# Altium schematic sheet FPSModule.SchDoc(FPSModule)
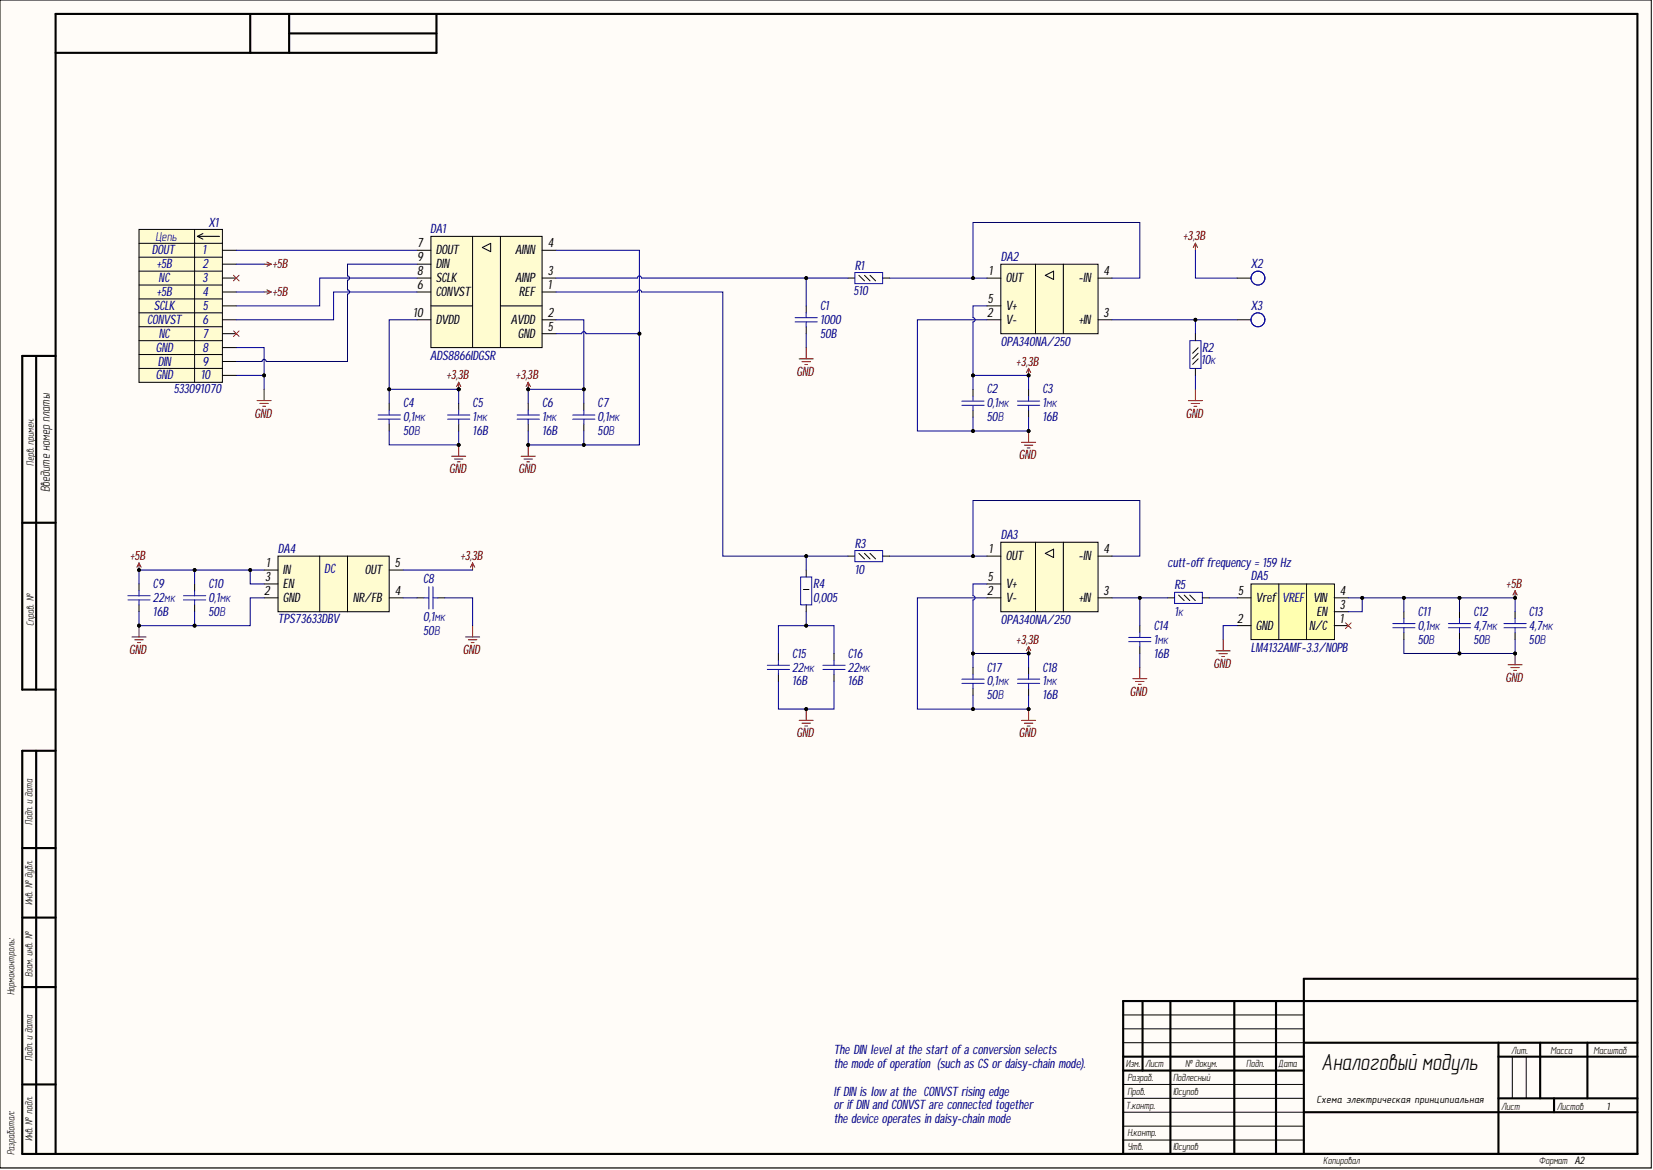
[diagram p0: FPSModule.SchDoc]

## Parts
C1  1000  Voltage: 50B  [C0402]
C2  0,1мк  Voltage: 50В  [C0402]
C3  1мк  Voltage: 16B  [C0603]
C4  0,1мк  Voltage: 50В  [C0402]
C5  1мк  Voltage: 16B  [C0603]
C6  1мк  Voltage: 16B  [C0603]
C7  0,1мк  Voltage: 50В  [C0402]
C8  0,1мк  Voltage: 50В  [C0402]
C9  22мк  Voltage: 16B  [C1210]
C10  0,1мк  Voltage: 50В  [C0402]
C11  0,1мк  Voltage: 50В  [C0402]
C12  4,7мк  Voltage: 50В  [C0805]
C13  4,7мк  Voltage: 50В  [C0805]
C14  1мк  Voltage: 16B  [C0603]
C15  22мк  Voltage: 16B  [C1210]
C16  22мк  Voltage: 16B  [C1210]
C17  0,1мк  Voltage: 50В  [C0402]
C18  1мк  Voltage: 16B  [C0603]
DA1  ADS8866  [MSOP10]
DA2  OPA340  Part Number: OPA340NA/250  [SOT23-5]
DA3  OPA340  Part Number: OPA340NA/250  [SOT23-5]
DA4  Part Number: TPS73633DBV  [SOT23-5]  (hidden comment: TPS736DBV)
DA5  LM4132  [SOT23-5]
R1  value 510  [R0402]  (hidden comment: Resistor_0,062Вт)
R2  value 10к  [R0402]  (hidden comment: Resistor_0,062Вт)
R3  value 10  [R0402]  (hidden comment: Resistor_0,062Вт)
R4  value 0,005  [R1206]  (hidden comment: Resistor_1Вт)
R5  value 1к  [R0402]  (hidden comment: Resistor_0,062Вт)
X1  Contact_1: DOUT  Contact_2: +5B  Contact_3: NC  Contact_5: SCLK  Contact_6: CONVST  Contact_8: GND  Contact_9: DIN  Part Number: 533091070  [SlimStack-10pin-angl-plg]  (hidden comment: Header_10_plg)
X2  SoldPoint  [SOLD_1PAD]  (value not drawn)
X3  SoldPoint  [SOLD_1PAD]  (value not drawn)

## Nets
+3,3B: C2.2 C3.2 C4.2 C5.2 C6.2 C7.2 C17.2 C18.2 DA1.2(AVDD) DA1.10(DVDD) DA2.5(V+) DA3.5(V+) DA4.5(OUT) X2.1
+5B: C9.2 C10.2 C11.2 C12.2 C13.2 DA4.1(IN) DA4.3(EN) DA5.3(EN) DA5.4(VIN) X1.2 X1.4
GND: C1.1 C2.1 C3.1 C4.1 C5.1 C6.1 C7.1 C8.1 C9.1 C10.1 C11.1 C12.1 C13.1 C14.1 C15.1 C16.1 C17.1 C18.1 DA1.4(AINN) DA1.5(GND) DA2.2(V-) DA3.2(V-) DA4.2(GND) DA5.2(GND) R2.1 X1.8 X1.10
NetC1_2: C1.2 DA1.3(AINP) R1.1
NetC8_2: C8.2 DA4.4(NR/FB)
NetC14_2: C14.2 DA3.3(+IN) R5.1
NetC15_2: C15.2 C16.2 R4.1
NetDA1_1: DA1.1(REF) R3.1 R4.2
NetDA1_6: DA1.6(CONVST) X1.6
NetDA1_7: DA1.7(DOUT) X1.1
NetDA1_8: DA1.8(SCLK) X1.5
NetDA1_9: DA1.9(DIN) X1.9
NetDA2_1: DA2.1(OUT) DA2.4(-IN) R1.2
NetDA2_3: DA2.3(+IN) R2.2 X3.1
NetDA3_1: DA3.1(OUT) DA3.4(-IN) R3.2
NetDA5_5: DA5.5(Vref) R5.2

Not connected: DA5 1(N/C); X1 3 7

## Library data of the parts
C1 = cap28: Конденсатор; Manufacture: Murata; Part Name: Ceramic; Part Number: GRM1555C1H102J; Database Table Name: Capacitors$; TKE: NP0; Component Kind: Standard; id: cap28; Library Name: MOP44.DbLib; Value: 1000
C2 C4 C7 C8 C10 C11 C17 = cap277: Конденсатор; Manufacture: Murata; Part Name: Ceramic; Part Number: GRM155R71H104KE14D; Database Table Name: Capacitors$; tga: 0,10%; TKE: X7R; Component Kind: Standard; id: cap277; Library Name: MOP44.DbLib; Value: 0,1мк
C3 C5 C6 C14 C18 = cap133: Конденсатор; Manufacture: Murata; Part Name: Ceramic; Part Number: GRM188R71C105KA12D**; Database Table Name: Capacitors$; TKE: X7R; Component Kind: Standard; id: cap133; Library Name: MOP44.DbLib; Value: 1мк
C9 C15 C16 = cap294: Конденсатор; Manufacture: TDK; Part Name: MLCC; Part Number: C3225X7R1C226M; Database Table Name: Capacitors$; tga: 0,20%; TKE: X7R; Component Kind: Standard; id: cap294; Library Name: MOP44.DbLib; Value: 22мк
C12 C13 = cap224: Конденсатор; Manufacture: Murata; Part Name: Ceramic; Part Number: GRM21BZ71H475KE15L; Database Table Name: Capacitors$; TKE: X7R; Component Kind: Standard; id: cap224; Library Name: MOP44.DbLib; Value: 4,7мк
DA1 = ADS8866IDGSR: 100-kSPS, serial interface, micropower, miniature,  single-ended input, SAR; Manufacture: Texas Instruments; Part Name: SAR analog-to-digital converter; Channels: 1; Current Consumption: 50nA; Database Table Name: ADC$; id: adc4; Interface: SPI; Power Supply: 2.7-3.6V; Resolution: 16bit; Sample frequence: 100 kS/s; Type Supply: Single Polar; ADC: SAR; Component Kind: Standard; Input channel: Single-Ended; Library Name: MOP44.DbLib; Part Number: ADS8866IDGSR
DA2 DA3 = amp23: Operational Amplifiers  MicroAmplifier; Manufacture: Texas Instruments; Bandwidth: 5,5MHz; Channels: 1; CMRR: 80dB; Database Table Name: Amplifier$; Input Bias Current: 0,2pA; Offset voltage: 150uB; PSRR: 100dB; Rail-to-rail: yes; Supply Current max: 0,75mA; Supply Voltage: 2,7-5,5B; Component Kind: Standard; id: amp23; Library Name: MOP44.DbLib; Part Name: Operational Amplifiers MicroAmplifier
DA4 = pwr113: 400-mA Low-Dropout Regulator with Reverse Current  Protection; Manufacturer: Texas Instruments; Current: 400mA; Database Table Name: PowerTransducer$; Dropout Voltage: 75mB; Input Voltage: 1,7-5,5В; Output Voltage: 3,3B; Component Kind: Standard; id: pwr113; Library Name: MOP44.DbLib; Part Name: Low-Dropout Regulator with Reverse Current Protection; Type: Linear
DA5 = LM4132AMF-3.3/NOPB: Precision Low Dropout Voltage Reference; Manufacturer: Texas Instruments; Accuracy: 0,0005; Database Table Name: VoltageReference$; id: ref4; Max input voltage: 5,5B; Output current: 20mA; Voltage min stabilize: 3,3B; Working current: 60uA; Component Kind: Standard; Library Name: MOP44.DbLib; Part Name: Precision Low Dropout Voltage Reference; Part Number: LM4132AMF-3.3/NOPB
R1 = res263: Резистор; Manufacture: Yageo; Part Number: RC0402FR-07510RL; Accuracy: 0,01; Database Table Name: Resistor$; Component Kind: Standard; id: res263; Library Name: MOP44.DbLib; Part Name: Resistor; Power: 0,062Вт
R2 = res294: Резистор; Manufacture: Yageo; Part Number: RC0402FR-0710KL; Accuracy: 0,01; Database Table Name: Resistor$; Component Kind: Standard; id: res294; Library Name: MOP44.DbLib; Part Name: Resistor; Power: 0,062Вт
R3 = res222: Резистор; Manufacture: Yageo; Part Number: RC0402FR-0710RL; Accuracy: 0,01; Database Table Name: Resistor$; Component Kind: Standard; id: res222; Library Name: MOP44.DbLib; Part Name: Resistor; Power: 0,062Вт
R4 = res1314: Резистор; Manufacture: Stackpole Electronics Inc; Part Number: CSRF1206FT5L00; Accuracy: 0,01; Database Table Name: Resistor$; Power: 1Вт; Component Kind: Standard; id: res1314; Library Name: MOP44.DbLib; Part Name: Resistor
R5 = res270: Резистор; Manufacture: Yageo; Part Number: RC0402FR-071KL; Accuracy: 0,01; Database Table Name: Resistor$; Component Kind: Standard; id: res270; Library Name: MOP44.DbLib; Part Name: Resistor; Power: 0,062Вт
X1 = connect227: Разъем - 10 конт. вилка; Manufacture: Molex; Part Name: SlimStack Board-to-Board plug; Database Table Name: Connector$; Etch: 0.8mm; Component Kind: Standard; Contact_4: +5B; Contact_7: NC; Contact_10: GND; id: connect227; Library Name: MOP44.DbLib
X2 X3 = connect220: Part Name: Пады на запайку; Database Table Name: Connector$; Component Kind: Standard; Contact_1: Contact_1; id: connect220; Library Name: MOP44.DbLib
